# Altium schematic sheet BMS_Current_Sense.SchDoc(BMS_Current_Sense)
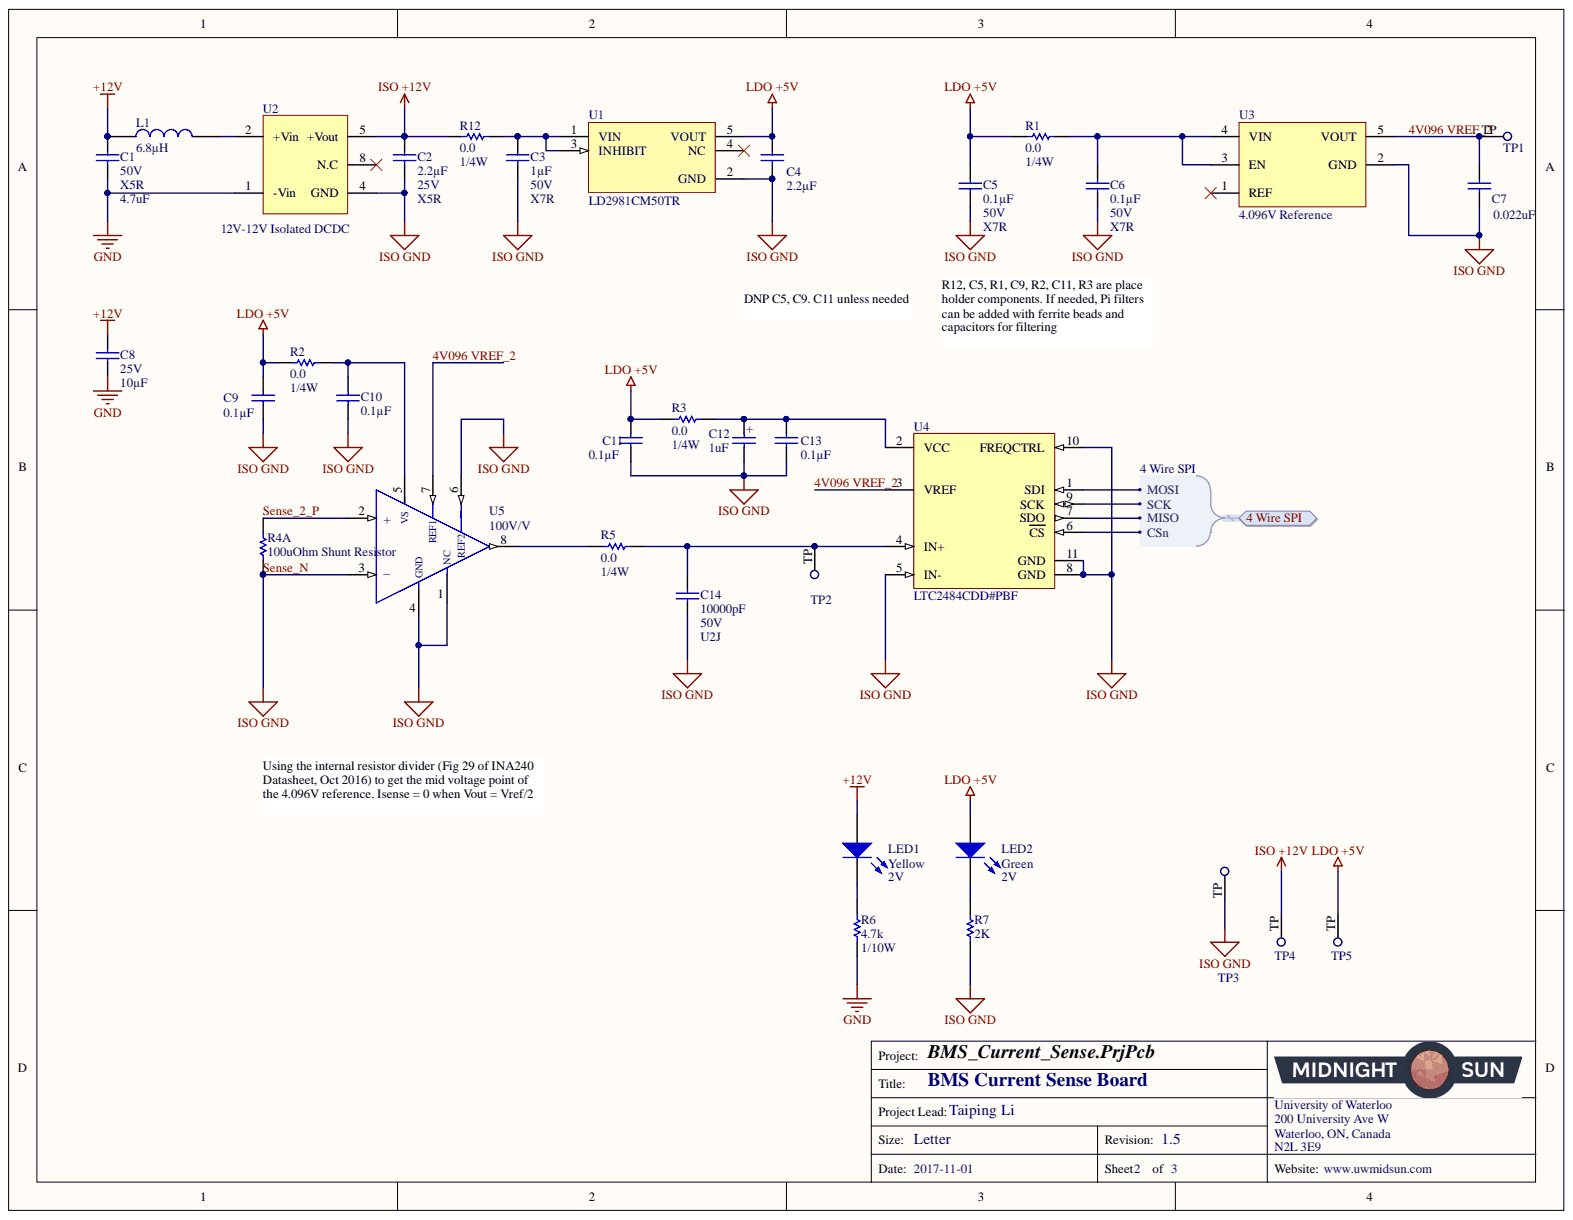
[diagram p0: BMS_Current_Sense.SchDoc]

## Parts
C1  value 4.7uF  Voltage - Rated: 50V  Temperature Coefficient: X5R  [CAP, 0805]  (hidden comment: CAP CER 4.7UF 50V 10% X5R 0805)
C2  Voltage: 25V  Temperature Coefficient: X5R  Capacitance: 2.2µF  [CAP, 0603]  (hidden comment: CAP CER 2.2UF 25V 10% X5R 0603)
C3  Voltage: 50V  Capacitance: 1µF  [CAP, 0603]  (hidden comment: CAP CER 1UF 50V 10% X7R 0603)
C4  Capacitance: 2.2µF  [CAP, 0603]  (hidden comment: CAP CER 2.2UF 25V 10% X5R 0603)
C5  Voltage: 50V  Temperature Coefficient: X7R  Capacitance: 0.1µF  [CAP, 0603]  (hidden comment: CAP CER 0.1UF 50V 10% X7R 0603)  (no BOM)
C6  Voltage: 50V  Temperature Coefficient: X7R  Capacitance: 0.1µF  [CAP, 0603]  (hidden comment: CAP CER 0.1UF 50V 10% X7R 0603)
C7  0.022uF  [CAP, 0603]
C8  Voltage - Rated: 25V  Capacitance: 10µF  [CAP, 0805]  (hidden comment: CAP CER 10uF 25V 10% X5R 0805)
C9  Capacitance: 0.1µF  [CAP, 0603]  (hidden comment: CAP CER 0.1UF 50V 10% X7R 0603)  (no BOM)
C10  Capacitance: 0.1µF  [CAP, 0603]  (hidden comment: CAP CER 0.1UF 50V 10% X7R 0603)
C11  Capacitance: 0.1µF  [CAP, 0603]  (hidden comment: CAP CER 0.1UF 50V 10% X7R 0603)  (no BOM)
C12  1uF  [CAP, TANTALUM 0805]
C13  Capacitance: 0.1µF  [CAP, 0603]  (hidden comment: CAP CER 0.1UF 50V 10% X7R 0603)
C14  Voltage: 50V  Temperature Coefficient: U2J  Capacitance: 10000pF  [CAP, 0603]  (hidden comment: CAP CER 10nF 50V 5% X7R 0603)
L1  Inductance: 6.8µH  [NLFV32T-6R8M-EF/IND 6.8uH]  (hidden comment: IND 6.8uH 260mA 20% 1210)
LED1  Voltage - Forward (Vf) (Typ): 2V  Color: Yellow  [LED_0603]  (hidden comment: LED YELLOW CLEAR 2.1V 0603)
LED2  Voltage - Forward (Vf) (Typ): 2V  Color: Green  [LED_0603]  (hidden comment: LED GREEN CLEAR 2V 0603)
R1  Resistance: 0.0  Power (Watts): 1/4W  [RES, 0603]  (hidden comment: RES 0.0 OHM 1/4W 0603)
R2  Resistance: 0.0  Power (Watts): 1/4W  [RES, 0603]  (hidden comment: RES 0.0 OHM 1/4W 0603)
R3  Resistance: 0.0  [RES, 0603]  (hidden comment: RES 0.0 OHM 1/4W 0603)
R4  100uOhm Shunt Resistor  [RES SHUNT 100UOHM 60MM]  (part A)
R5  Resistance: 0.0  Power (Watts): 1/4W  [RES, 0603]  (hidden comment: RES 0.0 OHM 1/4W 0603)
R6  Resistance (Ohms): 4.7k  Power (Watts): 1/10W  [RES, 0603]  (hidden comment: RES 4.7K OHM 1% 1/10W 0603)
R7  2K  [RES, 0603]
R12  Resistance: 0.0  Power (Watts): 1/4W  [RES, 0603]  (hidden comment: RES 0.0 OHM 1/4W 0603)
TP1  Test Point  [Test Point]  (value not drawn)
TP2  Test Point  [Test Point]  (value not drawn)
TP3  Test Point  [Test Point]  (value not drawn)
TP4  Test Point  [Test Point]  (value not drawn)
TP5  Test Point  [Test Point]  (value not drawn)
U1  Manufacturer Part Number 1: LD2981CM50TR  [SOT95P280X145-5N]  (hidden comment: IC REG LDO 5V 0.1A SOT23-5)
U2  Type: 12V-12V Isolated DCDC  [IC, Isolated DCDC]  (hidden comment: IC DCDC ISOLATED 12V 1W 8-SMD 5-LEAD)
U3  4.096V Reference  Voltage - Output (Min/Fixed): 4.096V  [IC OP AMP GP RR 10MHZ SOT-23-5]
U4  Manufacturer Part Number 1: LTC2484CDD#PBF  [IC ADC LTC2484 10-DFN]  (hidden comment: IC ADC 24BIT 10-DFN)
U5  100V/V  [IC CURRENT AMPLIFIER INA240 TSSOP-8]

## Ports
4 Wire SPI, 4 Wire SPI.CSn, 4 Wire SPI.MISO, 4 Wire SPI.MOSI, 4 Wire SPI.SCK

## Nets
+12V: C1.1 C8.1 L1.1 LED1.1
GND: C1.2 C8.2 R6.2 U2.1(-Vin)
ISO +12V: C2.1 R12.2 TP4.TP U2.5(+Vout)
LDO +5V: C4.1 C5.1 C9.1 C11.1 LED2.1 R1.2 R2.1 R3.1 TP5.TP U1.5(VOUT)
4V096 VREF_2: C7.1 TP1.TP U3.5(VOUT) U4.3(VREF) U5.7(REF1)  [also labelled 4V096 VREF]
Sense_2_P: R4.5 U5.2(+)  [also labelled Sense_P]
Sense_N: C2.2 C3.2 C4.2 C5.2 C6.2 C7.2 C9.2 C10.2 C11.2 C12.2 C13.2 C14.2 R4.6 R7.2 TP3.TP U1.2(GND) U2.4(GND) U3.2(GND) U4.5(IN-) U4.8(GND) U4.10(FREQCTRL) U4.11(GND) U5.1(NC) U5.3(_) U5.4(GND) U5.6
NetC3_1: C3.1 R12.1 U1.1(VIN) U1.3(INHIBIT)
NetC6_1: C6.1 R1.1 U3.3(EN) U3.4(VIN)
NetC10_1: C10.1 R2.2 U5.5(VS)
NetC12_1: C12.1 C13.1 R3.2 U4.2(VCC)
NetC14_1: C14.1 R5.2 TP2.TP U4.4(IN+)
NetL1_2: L1.2 U2.2(+Vin)
NetLED1_2: LED1.2 R6.1
NetLED2_2: LED2.2 R7.1
NetR5_1: R5.1 U5.8
NetU4_1: U4.1(SDI) ; port 4 Wire SPI
NetU4_6: U4.6(CS) ; port 4 Wire SPI
NetU4_7: U4.7(SDO) ; port 4 Wire SPI
NetU4_9: U4.9(SCK) ; port 4 Wire SPI

Not connected: U1 4(NC); U2 8(N.C); U3 1(REF)

## Library data of the parts
C1 = CAP CER 4.7UF 50V 10% X5R 0805: 4.7µF ±20% 50V Ceramic Capacitor X5R 0805 (2012 Metric); Manufacturer Part Number 1: GRT21BR61H475ME13L; Manufacturer 1: Murata Electronics North America; Description 1: CAP CER 4.7UF 50V X5R 0805; Tolerance: ±20%; Thickness (Max): 0.057" (1.45mm); Supplier Part Number 1: 490-12395-1-ND; Supplier 1: Digi-Key; Stock 1: 4286; Size / Dimension: 0.079" L x 0.049" W (2.00mm x 1.25mm); RoHS: RoHS Compliant; Ratings: AEC-Q200; Pricing 1: 1=0.56, 10=0.389, 100=0.2338, 500=0.17536, 1000=0.14808 (CAD); Part Status: Active; Packaging: Cut Tape (CT); Package / Case: 0805 (2012 Metric); Operating Temperature: -55°C ~ 85°C; Mounting Type: Surface Mount, MLCC; Lead Style: -; Lead Spacing: -; Height - Seated (Max): -; Features: -; ComponentLink1URL: http://search.murata.co.jp/Ceramy/image/img/A01X/G101/ENG/GRT21BR61H475ME13-01.pdf; ComponentLink1Description: http://search.murata.co.jp/Ceramy/image/img/A01X/G101/ENG/GRT21BR61H475ME13-01.pdf; Component Kind: Standard; Category: Capacitors; Applications: Automotive; Library Name: Schematic Diagrams.SchLib
C2 C4 = CAP CER 2.2UF 25V 10% X5R 0603: 2.2µF ±10% 25V Ceramic Capacitor X5R 0603 (1608 Metric); Manufacturer Part Number 1: GRM188R61E225KA12D; Manufacturer 1: Murata Electronics North America; Tolerance: ±10%; Thickness (Max): 0.035" (0.90mm); Supplier Part Number 1: 490-10731-1-ND; Supplier 1: Digi-Key; Size / Dimension: 0.063" L x 0.031" W (1.60mm x 0.80mm); Ratings: -; Part Status: Active; Packaging: Cut Tape (CT); Package / Case: 0603 (1608 Metric); Operating Temperature: -55°C ~ 85°C; Mounting Type: Surface Mount, MLCC; Lead Style: -; Lead Spacing: -; Height - Seated (Max): -; ComponentLink1URL: http://www.murata.com/~/media/webrenewal/support/library/catalog/products/capacitor/mlcc/c02e.ashx; ComponentLink1Description: http://www.murata.com/~/media/webrenewal/support/library/catalog/products/capacitor/mlcc/c02e.ashx; Component Kind: Standard; Library Name: Schematic Diagrams.SchLib
  C4: Voltage: 25V; Temperature Coefficient: X5R
C3 = CAP CER 1UF 50V 10% X7R 0603: 1µF ±10% 50V Ceramic Capacitor X7R 0603 (1608 Metric); Manufacturer Part Number 1: UMK107AB7105KA-T; Manufacturer 1: Taiyo Yuden; Tolerance: ±10%; Thickness (Max): 0.037" (0.95mm); Temperature Coefficient: X7R; Supplier Part Number 1: 587-3247-1-ND; Supplier 1: Digi-Key; Size / Dimension: 0.063" L x 0.031" W (1.60mm x 0.80mm); Ratings: -; Part Status: Active; Packaging: Cut Tape (CT); Package / Case: 0603 (1608 Metric); Operating Temperature: -55°C ~ 125°C; Mounting Type: Surface Mount, MLCC; Lead Style: -; Lead Spacing: -; Height - Seated (Max): -; ComponentLink1URL: http://www.yuden.co.jp/productdata/catalog/en/mlcc_all_e.pdf; ComponentLink1Description: http://www.yuden.co.jp/productdata/catalog/en/mlcc_all_e.pdf; Component Kind: Standard; Library Name: Schematic Diagrams.SchLib
C5 C6 C9-C11 C13 = CAP CER 0.1UF 50V 10% X7R 0603: 0.10µF ±10% 50V Ceramic Capacitor X7R 0603 (1608 Metric); Manufacturer Part Number 1: 06035C104KAT2A; Manufacturer 1: AVX Corporation; Tolerance: ±10%; Thickness (Max): 0.035" (0.90mm); Supplier Part Number 1: 478-5052-1-ND; Supplier 1: Digi-Key; Size / Dimension: 0.063" L x 0.032" W (1.60mm x 0.81mm); Ratings: -; Part Status: Active; Packaging: Cut Tape (CT); Package / Case: 0603 (1608 Metric); Operating Temperature: -55°C ~ 125°C; Mounting Type: Surface Mount, MLCC; Lead Style: -; Lead Spacing: -; Height - Seated (Max): -; ComponentLink1URL: http://datasheets.avx.com/X7RDielectric.pdf; ComponentLink1Description: http://datasheets.avx.com/X7RDielectric.pdf; Library Name: Schematic Diagrams.SchLib
  C6: Component Kind: Standard
  C9 C11: Voltage: 50V; Temperature Coefficient: X7R
  C10 C13: Voltage: 50V; Temperature Coefficient: X7R; Component Kind: Standard
C7 = CAP CER 0.022UF 50V 10% X7R 0603: 0.022µF ±10% 50V Ceramic Capacitor X7R 0603 (1608 Metric); Manufacturer Part Number 1: GRM188R71H223KA01D; Manufacturer 1: Murata Electronics North America; Description 1: CAP CER 0.022UF 50V X7R 0603; Voltage - Rated: 50V; Tolerance: ±10%; Thickness (Max): 0.035" (0.90mm); Temperature Coefficient: X7R; Supplier Part Number 1: 490-1517-1-ND; Supplier 1: Digi-Key; Stock 1: 612330; Size / Dimension: 0.063" L x 0.032" W (1.60mm x 0.80mm); RoHS: RoHS Compliant; Ratings: -; Pricing 1: 1=0.15, 10=0.111, 100=0.0499, 500=0.03564, 1000=0.02801 (CAD); Part Status: Active; Packaging: Cut Tape (CT); Package / Case: 0603 (1608 Metric); Operating Temperature: -55°C ~ 125°C; Mounting Type: Surface Mount, MLCC; Lead Style: -; Lead Spacing: -; Height - Seated (Max): -; Features: -; ComponentLink1URL: http://search.murata.co.jp/Ceramy/image/img/A01X/G101/ENG/GRM188R71H223KA01-01.pdf; ComponentLink1Description: http://search.murata.co.jp/Ceramy/image/img/A01X/G101/ENG/GRM188R71H223KA01-01.pdf; Component Kind: Standard; Category: Capacitors; Applications: General Purpose; Library Name: Schematic Diagrams.SchLib
C8 = CAP CER 10uF 25V 10% X5R 0805: 10µF ±10% 25V Ceramic Capacitor X5R 0805 (2012 Metric); Manufacturer Part Number: GRM21BR61E106KA73L; Manufacturer: Murata Electronics North America; Tolerance: ±10%; Thickness (Max): 0.053" (1.35mm); Temperature Coefficient: X5R; Supplier Part Number 1: 490-5523-1-ND; Supplier 1: Digi-Key; Stock 1: 1695157; Size / Dimension: 0.079" L x 0.049" W (2.00mm x 1.25mm); RoHS: RoHS Compliant; Ratings: -; Pricing 1: 1=0.3, 10=0.214, 100=0.1008, 500=0.07068, 1000=0.05851 (CAD); Part Status: Active; Packaging: Cut Tape (CT); Package / Case: 0805 (2012 Metric); Operating Temperature: -55°C ~ 85°C; Mounting Type: Surface Mount, MLCC; Lead Style: -; Lead Spacing: -; Height - Seated (Max): -; Features: -; ComponentLink1URL: http://search.murata.co.jp/Ceramy/image/img/A01X/G101/ENG/GRM21BR61E106KA73-01.pdf; ComponentLink1Description: http://search.murata.co.jp/Ceramy/image/img/A01X/G101/ENG/GRM21BR61E106KA73-01.pdf; Component Kind: Standard; Category: Capacitors; Applications: General Purpose; Library Name: Schematic Diagrams.SchLib
C12 = CAP TANT 1UF 25V 10% 0805: 1µF Molded Tantalum Capacitors 25V 0805 (2012 Metric) 8 Ohm; Manufacturer Size Code: R; Manufacturer Part Number 1: TAJR105K025RNJ; Manufacturer 1: AVX Corporation; Tolerance: ±10%; ESR (Equivalent Series Resistance): 8 Ohm; Value: 1uF; Type: Molded; Supplier Part Number 1: 478-8928-1-ND; Supplier 1: Digi-Key; Stock 1: 16110; Size / Dimension: 0.081" L x 0.051" W (2.05mm x 1.30mm); RoHS: RoHS Compliant; Pricing 1: 1=0.95, 10=0.672, 100=0.4428, 500=0.32802, 1000=0.27882 (CAD); Part Status: Active; Packaging: Cut Tape (CT); Package / Case: 0805 (2012 Metric); Operating Temperature: -55°C ~ 125°C; Mounting Type: Surface Mount; Lifetime @ Temp.: -; Lead Spacing: -; Height - Seated (Max): 0.047" (1.20mm); Features: General Purpose; Failure Rate: -; Description 1: CAP TANT 1UF 25V 10% 0805; ComponentLink1URL: http://datasheets.avx.com/TAJ_LOW_PROFILE.pdf; ComponentLink1Description: http://datasheets.avx.com/TAJ_LOW_PROFILE.pdf; Component Kind: Standard; Category: Capacitors; Library Name: Schematic Diagrams.SchLib
C14 = CAP CER 10nF 50V 5% X7R 0603: 10000pF ±5% 50V Ceramic Capacitor U2J 0603 (1608 Metric); Manufacturer Part Number 1: C0603C103J5JAC7867; Manufacturer 1: KEMET; Tolerance: ±5%; Thickness (Max): 0.034" (0.87mm); Supplier Part Number 1: 399-13384-1-ND; Supplier 1: Digi-Key; Size / Dimension: 0.063" L x 0.032" W (1.60mm x 0.80mm); Ratings: -; Part Status: Active; Packaging: Cut Tape (CT); Package / Case: 0603 (1608 Metric); Operating Temperature: -55°C ~ 125°C; Mounting Type: Surface Mount, MLCC; Lead Style: -; Lead Spacing: -; Height - Seated (Max): -; Failure Rate: -; ComponentLink1URL: http://www.kemet.com/docfinder?Partnumber=C0603C103J5JAC7867; ComponentLink1Description: http://www.kemet.com/docfinder?Partnumber=C0603C103J5JAC7867; Component Kind: Standard; Library Name: Schematic Diagrams.SchLib
L1 = IND 6.8uH 260mA 20% 1210: 6.8µH Shielded Wirewound Inductor 260mA 204 mOhm Max 1210 (3225 Metric); Manufacturer Part Number 1: NLFV32T-6R8M-EF; Manufacturer 1: TDK Corporation; Material - Core: Ferrite; Type: Wirewound; Tolerance: ±20%; Supplier Part Number 1: 445-15776-1-ND; Supplier Device Package: 1210 (3225 Metric); Supplier 1: Digi-Key; Size / Dimension: 0.126" L x 0.098" W (3.20mm x 2.50mm); Shielding: Shielded; Ratings: -; Q @ Freq: -; Part Status: Active; Packaging: Cut Tape (CT); Package / Case: 1210 (3225 Metric); Operating Temperature: -40°C ~ 105°C; Mounting Type: Surface Mount; Height - Seated (Max): 0.094" (2.40mm); Frequency - Self Resonant: -; DC Resistance (DCR): 204 mOhm Max; Current Rating: 260mA; Current - Saturation: -; ComponentLink1URL: https://product.tdk.com/info/en/catalog/datasheets/inductor_commercial_decoupling_nlfv32-ef_en.pdf; ComponentLink1Description: https://product.tdk.com/info/en/catalog/datasheets/inductor_commercial_decoupling_nlfv32-ef_en.pdf; Component Kind: Standard; Library Name: Schematic Diagrams.SchLib
LED1 = LED YELLOW CLEAR 2.1V 0603: Yellow 590nm LED Indication - Discrete 2V 0603 (1608 Metric); Manufacturer Part Number: 150060YS75000; Manufacturer: Wurth Electronics Inc.; Wavelength - Peak: 595nm; Viewing Angle: 140°; Millicandela Rating: 120mcd; Lens Style/Size: Rectangle with Flat Top, 1.10mm x 0.70mm; Lens Color: Colorless; Current - Test: 20mA; Wavelength - Dominant: 590nm; Supplier Part Number 1: 732-4981-1-ND; Supplier Device Package: 0603; Supplier 1: Digi-Key; Stock 1: 10523; Size / Dimension: 1.60mm L x 0.80mm W; RoHS: RoHS Compliant; Pricing 1: 1=0.21, 100=0.1617, 500=0.13964 (CAD); Part Status: Active; Packaging: Cut Tape (CT); Package / Case: 0603 (1608 Metric); Mounting Type: Surface Mount; Lens Transparency: Clear; Height (Max): 0.80mm; Features: -; ComponentLink1URL: http://katalog.we-online.de/led/datasheet/150060YS75000.pdf; ComponentLink1Description: http://katalog.we-online.de/led/datasheet/150060YS75000.pdf; Component Kind: Standard; Category: Optoelectronics; Library Name: Schematic Diagrams.SchLib
LED2 = LED GREEN CLEAR 2V 0603: Green 572nm LED Indication - Discrete 2V 0603 (1608 Metric); Manufacturer Part Number: 150060VS75000; Manufacturer: Wurth Electronics Inc.; Wavelength - Dominant: 570nm; Viewing Angle: 140°; Millicandela Rating: 40mcd; Lens Style/Size: Rectangle with Flat Top, 1.10mm x 0.70mm; Lens Color: Colorless; Current - Test: 20mA; Wavelength - Peak: 572nm; Supplier Part Number 1: 732-4980-1-ND; Supplier Device Package: 0603; Supplier 1: Digi-Key; Stock 1: 9408; Size / Dimension: 1.60mm L x 0.80mm W; RoHS: RoHS Compliant; Pricing 1: 1=0.21, 100=0.1617, 500=0.13964 (CAD); Part Status: Active; Packaging: Cut Tape (CT); Package / Case: 0603 (1608 Metric); Mounting Type: Surface Mount; Lens Transparency: Clear; Height (Max): 0.80mm; Features: -; ComponentLink1URL: http://katalog.we-online.de/led/datasheet/150060VS75000.pdf; ComponentLink1Description: http://katalog.we-online.de/led/datasheet/150060VS75000.pdf; Component Kind: Standard; Category: Optoelectronics; Library Name: Schematic Diagrams.SchLib
R1-R3 R5 R12 = RES 0.0 OHM 1/4W 0603: RES SMD 0.0 OHM JUMPER 1/4W 0603; Manufacturer Part Number 1: CRCW06030000Z0EAHP; Manufacturer 1: Vishay Dale; Supplier Part Number 1: 541-0.0SBCT-ND; Supplier Device Package: 0603; Supplier 1: Digi-Key; Size / Dimension: 0.063" L x 0.033" W (1.60mm x 0.85mm); Part Status: Active; Packaging: Cut Tape (CT); Package / Case: 0603 (1608 Metric); Operating Temperature: -55°C ~ 155°C; Height - Seated (Max): 0.022" (0.55mm); Failure Rate: -; Composition: Thick Film; ComponentLink1URL: http://www.vishay.com/docs/20043/crcwhpe3.pdf; ComponentLink1Description: http://www.vishay.com/docs/20043/crcwhpe3.pdf; Component Kind: Standard; Library Name: Schematic Diagrams.SchLib
  R3: Power (Watts): 1/4W
R4 = RES SHUNT 100UOHM 5% 36W 60MM: 100 µOhms ±5% 36W Shunt, Battery Specialized Resistor Metal Element ±175ppm/°C Shunt Fixture, 60mm Pitch; Manufacturer Part Number 1: WSBM8518L1000JK; Manufacturer 1: Vishay Dale; Type: Shunt, Battery; Tolerance: ±5%; Temperature Coefficient: ±175ppm/°C; Supplier Part Number 1: 541-1906-ND; Supplier 1: Digi-Key; Stock 1: 0; RoHS: RoHS Compliant; Pricing 1: 1=16.24, 10=14.764, 25=13.2876, 50=11.442, 100=10.5192, 250=9.9656, 500=9.5965, 1000=9.15359 (CAD); Power (Watts): 36W; Part Status: Active; Packaging: Bulk; Operating Temperature: -65°C ~ 170°C; Mounting Type: Shunt Fixture, 60mm Pitch; Description 1: RES SHUNT 100 UOHM 5% 36W; Composition: Metal Element; ComponentLink1URL: http://www.vishay.com/docs/31094/wsbm8518.pdf; ComponentLink1Description: http://www.vishay.com/docs/31094/wsbm8518.pdf; Component Kind: Standard; Category: Resistors; Applications: -; Library Name: Schematic Diagrams.SchLib
R6 = RES 4.7K OHM 1% 1/10W 0603: 4.7k Ohm ±1% 0.1W, 1/10W Chip Resistor 0603 (1608 Metric) Moisture Resistant Thick Film; Manufacturer Part Number 1: RC0603FR-074K7L; Manufacturer 1: Yageo; Temperature Coefficient: ±100ppm/°C; Description 1: RES SMD 4.7K OHM 1% 1/10W 0603; Tolerance: ±1%; Supplier Part Number 1: 311-4.70KHRCT-ND; Supplier Device Package: 0603; Supplier 1: Digi-Key; Stock 1: 3487566; Size / Dimension: 0.063" L x 0.031" W (1.60mm x 0.80mm); RoHS: RoHS Compliant; Pricing 1: 1=0.16, 10=0.022, 100=0.0089, 1000=0.00402, 2500=0.00349 (CAD); Part Status: Active; Packaging: Cut Tape (CT); Package / Case: 0603 (1608 Metric); Operating Temperature: -55°C ~ 155°C; Height - Seated (Max): 0.022" (0.55mm); Features: Moisture Resistant; Failure Rate: -; Composition: Thick Film; ComponentLink1URL: http://www.yageo.com/documents/recent/PYu-RC_Group_51_RoHS_L_7.pdf; ComponentLink1Description: http://www.yageo.com/documents/recent/PYu-RC_Group_51_RoHS_L_7.pdf; Component Kind: Standard; Category: Resistors; Library Name: Schematic Diagrams.SchLib
R7 = RES 2K OHM 1% 1/10W 0603: 2k Ohm ±1% 0.1W, 1/10W Chip Resistor 0603 (1608 Metric) Moisture Resistant Thick Film; Manufacturer Part Number: RC0603FR-072KL; Manufacturer: Yageo; Temperature Coefficient: ±100ppm/°C; Tolerance: ±1%; Supplier Part Number 1: 311-2.00KHRCT-ND; Supplier Device Package: 0603; Supplier 1: Digi-Key; Stock 1: 1750701; Size / Dimension: 0.063" L x 0.031" W (1.60mm x 0.80mm); RoHS: RoHS Compliant; Resistance (Ohms): 2k; Pricing 1: 1=0.15, 10=0.023, 100=0.0093, 1000=0.00415, 2500=0.0036 (CAD); Power (Watts): 0.1W, 1/10W; Part Status: Active; Packaging: Cut Tape (CT); Package / Case: 0603 (1608 Metric); Operating Temperature: -55°C ~ 155°C; Height - Seated (Max): 0.022" (0.55mm); Features: Moisture Resistant; Failure Rate: -; Composition: Thick Film; ComponentLink1URL: http://www.yageo.com/documents/recent/PYu-RC_Group_51_RoHS_L_7.pdf; ComponentLink1Description: http://www.yageo.com/documents/recent/PYu-RC_Group_51_RoHS_L_7.pdf; Component Kind: Standard; Category: Resistors; Library Name: Schematic Diagrams.SchLib
U1 = IC REG LDO 5V 0.1A SOT23-5: Linear Voltage Regulator IC Positive Fixed Output 5V 100mA SOT-23-5; Manufacturer 1: STMicroelectronics; Voltage - Input: Up to 16V; Voltage - Dropout (Typical): 0.18V @ 100mA; Voltage - Output: 5V; Supplier Part Number 1: 497-7787-1-ND; Supplier Device Package: SOT-23-5; Supplier 1: Digi-Key; Regulator Topology: Positive Fixed; Part Status: Active; Packaging: Cut Tape (CT); Package / Case: SC-74A, SOT-753; Operating Temperature: -40°C ~ 125°C; Mounting Type: Surface Mount; Current - Output: 100mA; Current - Limit (Min): -; ComponentLink1URL: http://www.st.com/content/ccc/resource/technical/document/datasheet/group1/b7/b5/a1/83/9c/bd/4a/90/CD00001635/files/CD00001635.pdf/jcr:content/translations/en.CD00001635.pdf; ComponentLink1Description: http://www.st.com/content/ccc/resource/technical/document/datasheet/group1/b7/b5/a1/83/9c/bd/4a/90/CD00001635/files/CD00001635.pdf/jcr:content/translations/en.CD00001635.pdf; Component Kind: Standard; Library Name: Schematic Diagrams.SchLib
U2 = IC DCDC ISOLATED 12V 1W 8-SMD 5-LEAD: Isolated Module DC DC Converter 1 Output 12V 84mA 10.8V - 13.2V Input; Manufacturer Part Number 1: ISE1212A; Manufacturer 1: XP Power; Voltage - Isolation: 1.5kV (1500V); Number of Outputs: 1; Efficiency: 81%; Voltage - Output 3: -; Voltage - Output 2: -; Voltage - Output 1: 12V; Voltage - Input (Min): 10.8V; Voltage - Input (Max): 13.2V; Supplier Part Number 1: 1470-2950-1-ND; Supplier 1: Digi-Key; Size / Dimension: 0.50" L x 0.44" W x 0.29" H (12.7mm x 11.2mm x 7.3mm); Power: 1W; Part Status: Active; Packaging: Cut Tape (CT); Package / Case: 8-SMD Module, 5 Leads; Operating Temperature: -40°C ~ 105°C; Mounting Type: Surface Mount; Current - Output (Max): 84mA; ComponentLink1URL: http://www.xppower.com/Portals/0/pdfs/SF_ISE.pdf; ComponentLink1Description: http://www.xppower.com/Portals/0/pdfs/SF_ISE.pdf; Component Kind: Standard; Library Name: Schematic Diagrams.SchLib
U3 = IC VREF SERIES 4.096V 5MA SOT23-5: Series Voltage Reference IC ±0.2% 5mA SOT-23-5; Manufacturer Part Number 1: LM4120AIM5-4.1/NOPB; Manufacturer 1: Texas Instruments; Voltage - Input: 2 V ~ 12 V; Temperature Coefficient: 50ppm/°C; Output Type: Fixed; Noise - 10Hz to 10kHz: 36µVp-p; Noise - 0.1Hz to 10Hz: 20µVp-p; Description 1: IC VREF SERIES 4.096V SOT23-5; Current - Supply: 275µA; Tolerance: ±0.2%; Supplier Part Number 1: LM4120AIM5-4.1/NOPBCT-ND; Supplier Device Package: SOT-23-5; Supplier 1: Digi-Key; Stock 1: 10567; RoHS: RoHS Compliant; Reference Type: Series; Pricing 1: 1=2.93, 10=2.633, 100=2.1166, 500=1.73892 (CAD); Part Status: Active; Packaging: Cut Tape (CT); Package / Case: SC-74A, SOT-753; Operating Temperature: -40°C ~ 85°C (TA); Mounting Type: Surface Mount; ComponentLink1URL: http://www.ti.com/lit/ds/symlink/lm4120.pdf; ComponentLink1Description: http://www.ti.com/lit/ds/symlink/lm4120.pdf; Component Kind: Standard; Category: Integrated Circuits (ICs); Library Name: Schematic Diagrams.SchLib
U4 = IC ADC 24BIT 10-DFN: 24 Bit Analog to Digital Converter 1 Input 1 Sigma-Delta 10-DFN (3x3); Manufacturer 1: Linear Technology; Voltage - Supply, Digital: 2.7 V ~ 5.5 V; Sampling Rate (Per Second): 7.5; Reference Type: External; Number of Inputs: 1; Number of Bits: 24; Input Type: Differential; Data Interface: SPI; Configuration: MUX-ADC; Voltage - Supply, Analog: 2.7 V ~ 5.5 V; Supplier Part Number 1: LTC2484CDD#PBF-ND; Supplier Device Package: 10-DFN (3x3); Supplier 1: Digi-Key; Ratio - S/H: -; Part Status: Active; Packaging: Tube; Package / Case: 10-WFDFN Exposed Pad; Operating Temperature: 0°C ~ 70°C; Number of A/D Converters: 1; ComponentLink1URL: http://www.linear.com/docs/7978; ComponentLink1Description: http://www.linear.com/docs/7978; Component Kind: Standard; Architecture: Sigma-Delta; Library Name: Schematic Diagrams.SchLib
U5 = IC CURRENT AMPLIFIER INA240 8-TSSOP: Current Sense Amplifier 1 Circuit 8-TSSOP; Manufacturer Part Number 1: INA240A3PWR; Manufacturer 1: Texas Instruments; Voltage - Supply, Single/Dual (±): 2.7 V ~ 5.5 V; Slew Rate: 2 V/µs; Description 1: IC AMP CURRENT SENSE 8-TSSOP; Current - Supply: 1.8mA; Supplier Part Number 1: 296-45090-1-ND; Supplier Device Package: 8-TSSOP; Supplier 1: Digi-Key; Stock 1: 1286; RoHS: RoHS Compliant; Pricing 1: 1=3.79, 10=3.397, 100=2.7311, 500=2.24388, 1000=1.85921 (CAD); Part Status: Active; Packaging: Cut Tape (CT); Package / Case: 8-TSSOP (0.173", 4.40mm Width); Output Type: -; Operating Temperature: -40°C ~ 125°C; Mounting Type: Surface Mount; ComponentLink1URL: http://www.ti.com/lit/ds/symlink/ina240.pdf; ComponentLink1Description: http://www.ti.com/lit/ds/symlink/ina240.pdf; Component Kind: Standard; Category: Integrated Circuits (ICs); Amplifier Type: Current Sense; Library Name: Schematic Diagrams.SchLib
TP1-TP5 = Test Point: Component Kind: Standard; Library Name: Schematic Diagrams.SchLib
